# Altium schematic sheet 06_USB.SchDoc
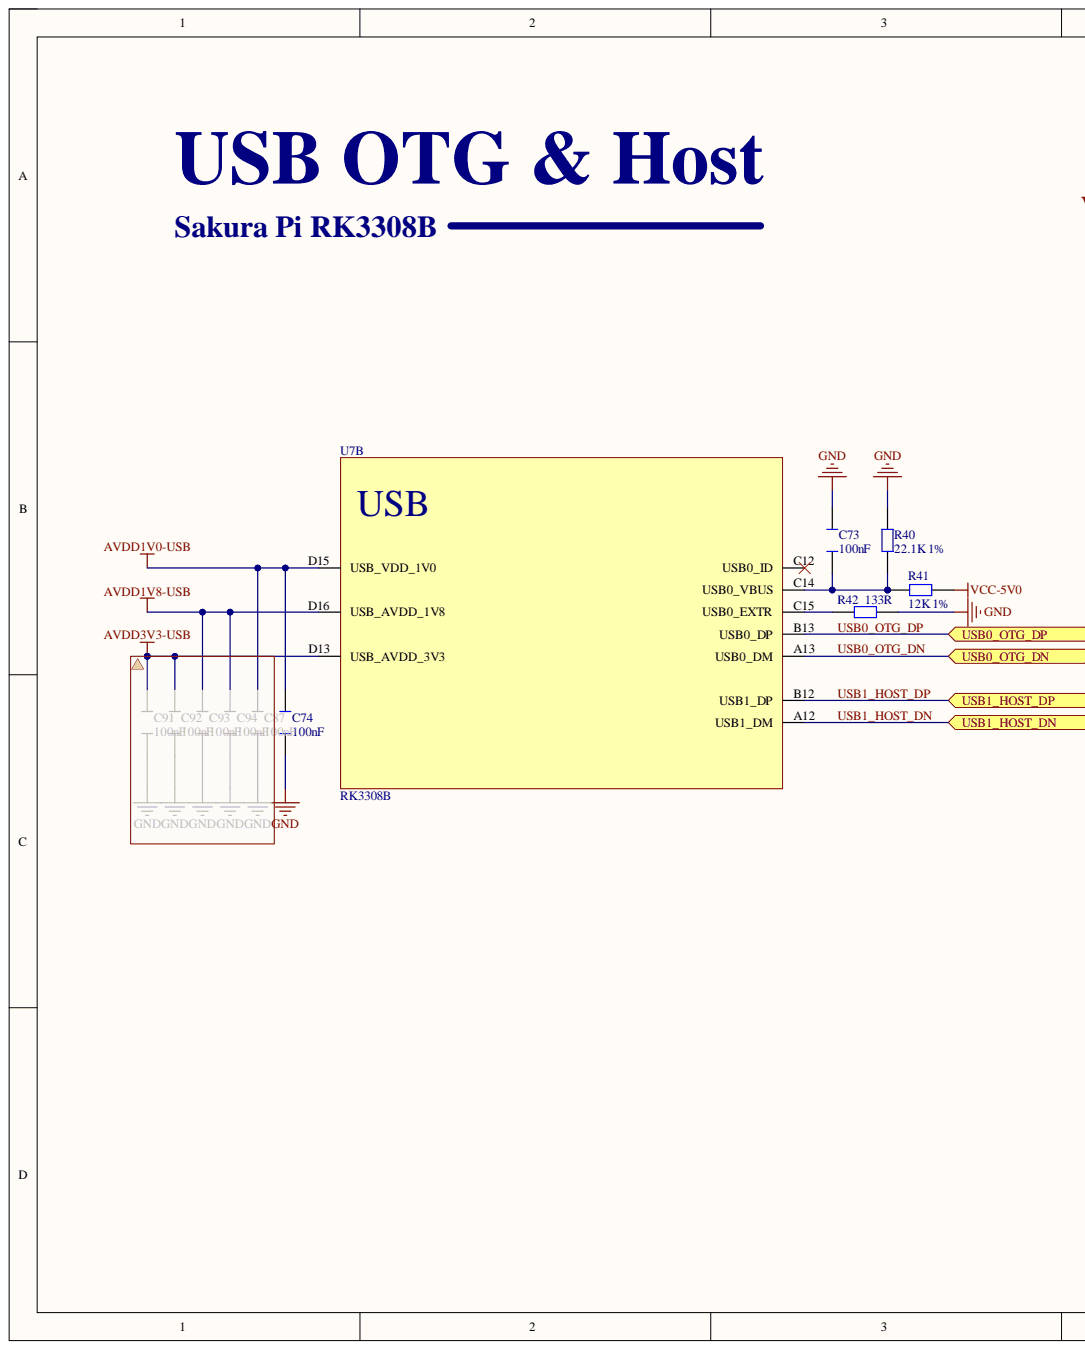
[diagram p0: 06_USB.SchDoc, left]
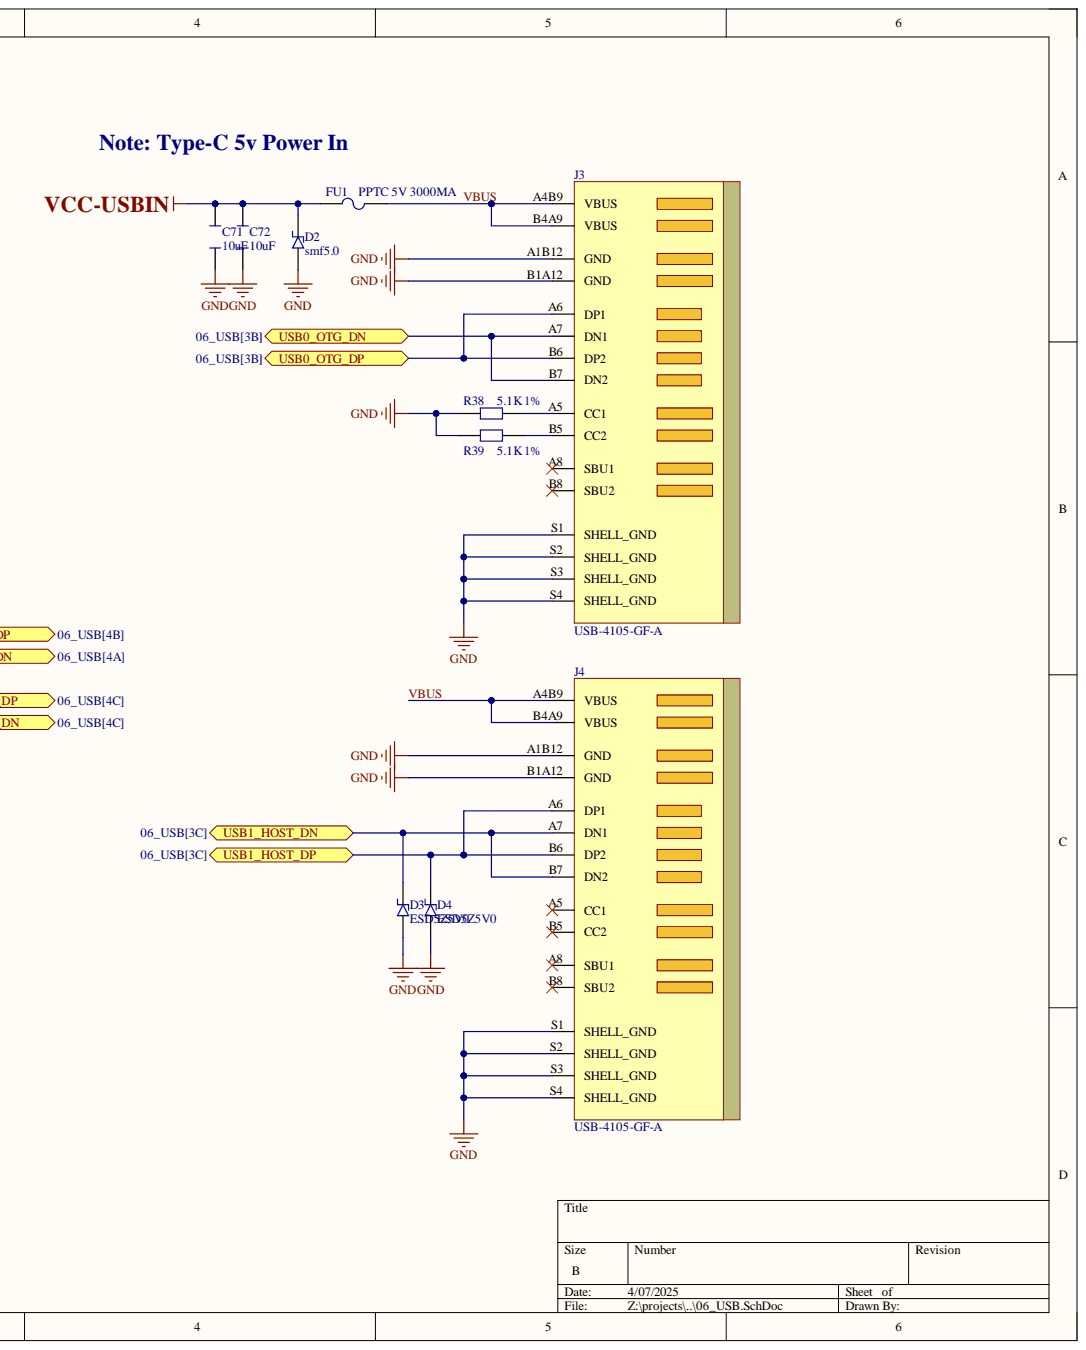
[diagram p1: 06_USB.SchDoc, right]

## Parts
C71  10uF  [C0603]
C72  10uF  [C0603]
C73  100nF  [C0402]
C74  100nF  [C0402]
D2  smf5.0  [SODFL3718X102N]
D3  ESD5Z5V0  [ESD5Z5V0]
D4  ESD5Z5V0  [ESD5Z5V0]
FU1  PPTC 5V 3000MA  [FU1206]
J3  USB-4105-GF-A  [USB-4105-GF-A]
J4  USB-4105-GF-A  [USB-4105-GF-A]
R38  5.1K 1%  [R0402]
R39  5.1K 1%  [R0402]
R40  22.1K 1%  [R0402]
R41  12K 1%  [R0402]
R42  133R  [R0402]
U7  RK3308B  [RK3308B]  (part B)

## Ports
USB0_OTG_DN, USB0_OTG_DP, USB1_HOST_DN, USB1_HOST_DP

## Nets
AVDD1V0-USB: C74.2 U7.D15(USB_VDD_1V0)
AVDD1V8-USB: U7.D16(USB_AVDD_1V8)
AVDD3V3-USB: U7.D13(USB_AVDD_3V3)
GND: C71.1 C72.1 C73.2 C74.1 D2.2 D3.1 D4.1 J3.A1B12(GND) J3.B1A12(GND) J3.S1(SHELL_GND) J3.S2(SHELL_GND) J3.S3(SHELL_GND) J3.S4(SHELL_GND) J4.A1B12(GND) J4.B1A12(GND) J4.S1(SHELL_GND) J4.S2(SHELL_GND) J4.S3(SHELL_GND) J4.S4(SHELL_GND) R38.1 R39.1 R40.2 R42.2
VCC-5V0: R41.2
VCC-USBIN: C71.2 C72.2 D2.1 FU1.1
USB0_OTG_DN: J3.A7(DN1) J3.B7(DN2) U7.A13(USB0_DM) ; port USB0_OTG_DN
USB0_OTG_DP: J3.A6(DP1) J3.B6(DP2) U7.B13(USB0_DP) ; port USB0_OTG_DP
USB1_HOST_DN: D3.2 J4.A7(DN1) J4.B7(DN2) U7.A12(USB1_DM) ; port USB1_HOST_DN
USB1_HOST_DP: D4.2 J4.A6(DP1) J4.B6(DP2) U7.B12(USB1_DP) ; port USB1_HOST_DP
VBUS: FU1.2 J3.A4B9(VBUS) J3.B4A9(VBUS) J4.A4B9(VBUS) J4.B4A9(VBUS)
NetC73_1: C73.1 R40.1 R41.1 U7.C14(USB0_VBUS)
NetJ3_A5: J3.A5(CC1) R38.2
NetJ3_B5: J3.B5(CC2) R39.2
NetR42_1: R42.1 U7.C15(USB0_EXTR)

Not connected: J3 A8(SBU1) B8(SBU2); J4 A5(CC1) A8(SBU1) B5(CC2) B8(SBU2); U7 C12(USB0_ID)

## Library data of the parts
C71 C72 = C_10UF: Component Kind: Standard; Library Name: rk3308b.SchLib
C73 C74 = C_100NF: Component Kind: Standard; Library Name: rk3308b.SchLib
D2 = SMF5_0A: Component Kind: Standard; Library Name: rk3308b.SchLib
FU1 = FU5V3A: Component Kind: Standard; Library Name: rk3308b.SchLib
R38 R39 = R_5.1K: Component Kind: Standard; Library Name: rk3308b.SchLib
R40 = R_22.1K_P1: Component Kind: Standard; Library Name: rk3308b.SchLib
R41 = R_12K_P1: Component Kind: Standard; Library Name: rk3308b.SchLib
R42 = R_133R: Component Kind: Standard; Library Name: rk3308b.SchLib
D3 D4 = ESD5Z5V0: Component Kind: Standard; Library Name: rk3308b.SchLib
J3 J4 = USB-4105-GF-A: Component Kind: Standard; Library Name: rk3308b.SchLib
U7 = RK3308B: Component Kind: Standard; Library Name: rk3308b.SchLib
